# Altium schematic sheet microcontroller.SchDoc(microcontroller)
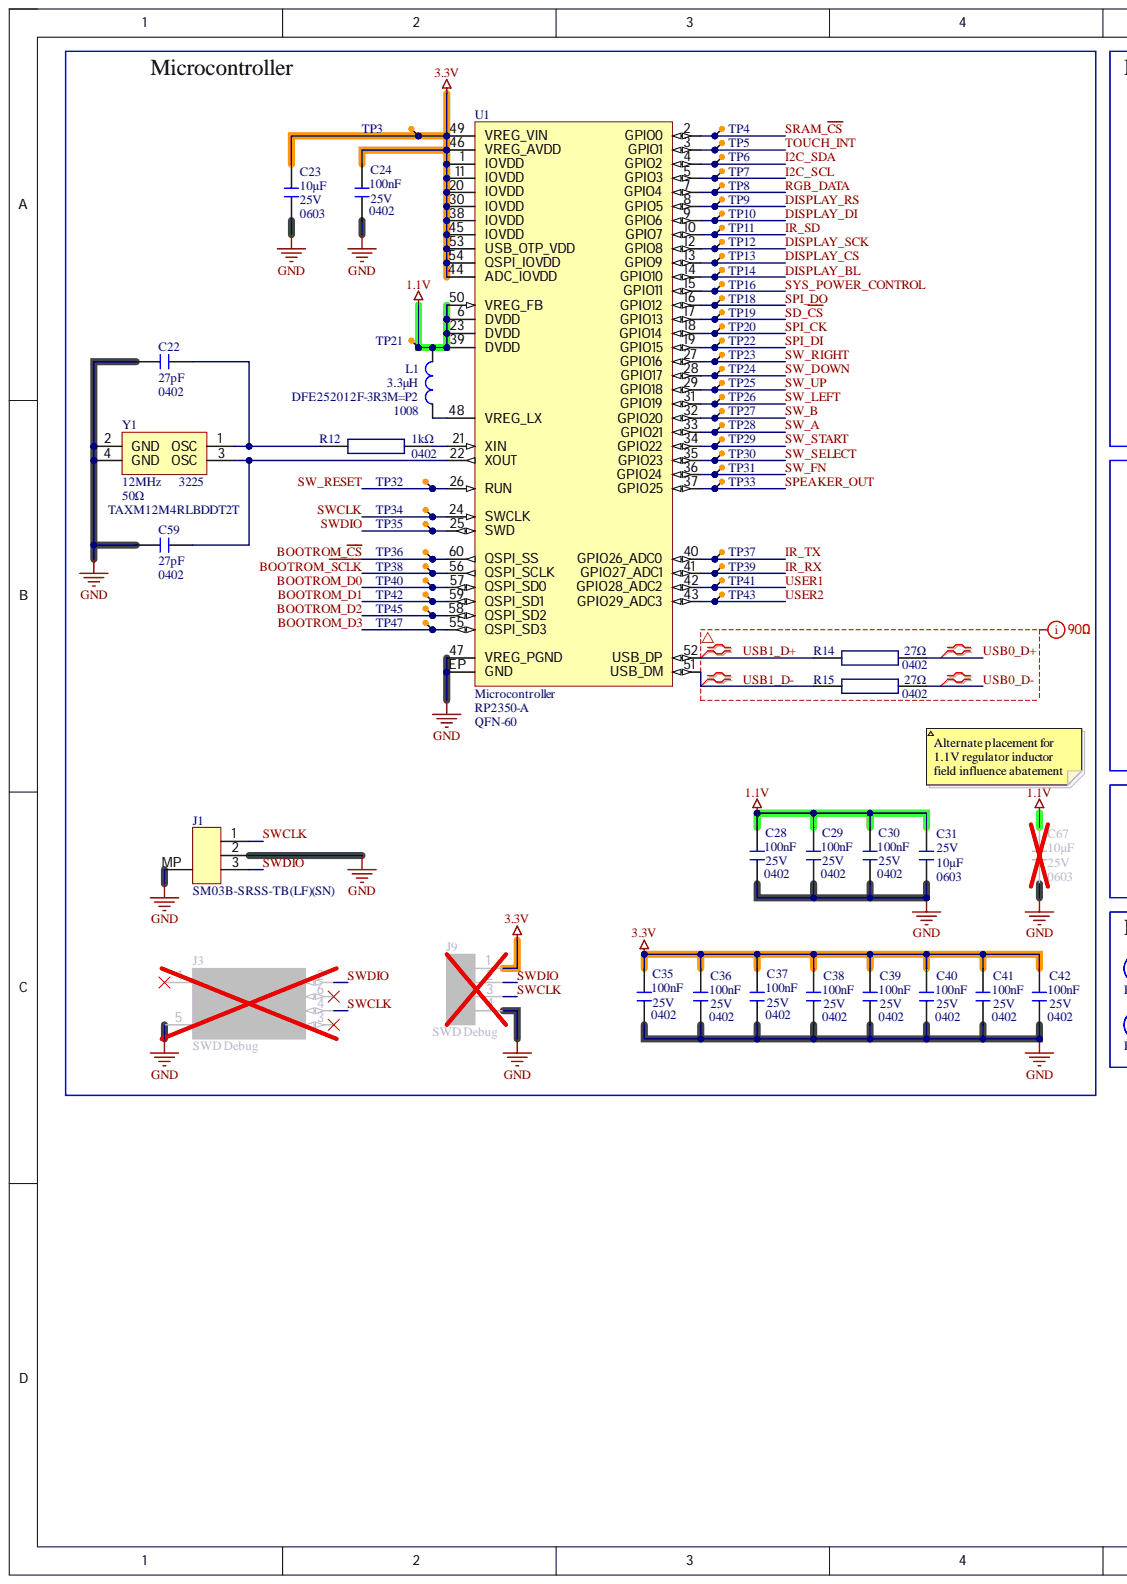
[diagram p0: microcontroller.SchDoc, left]
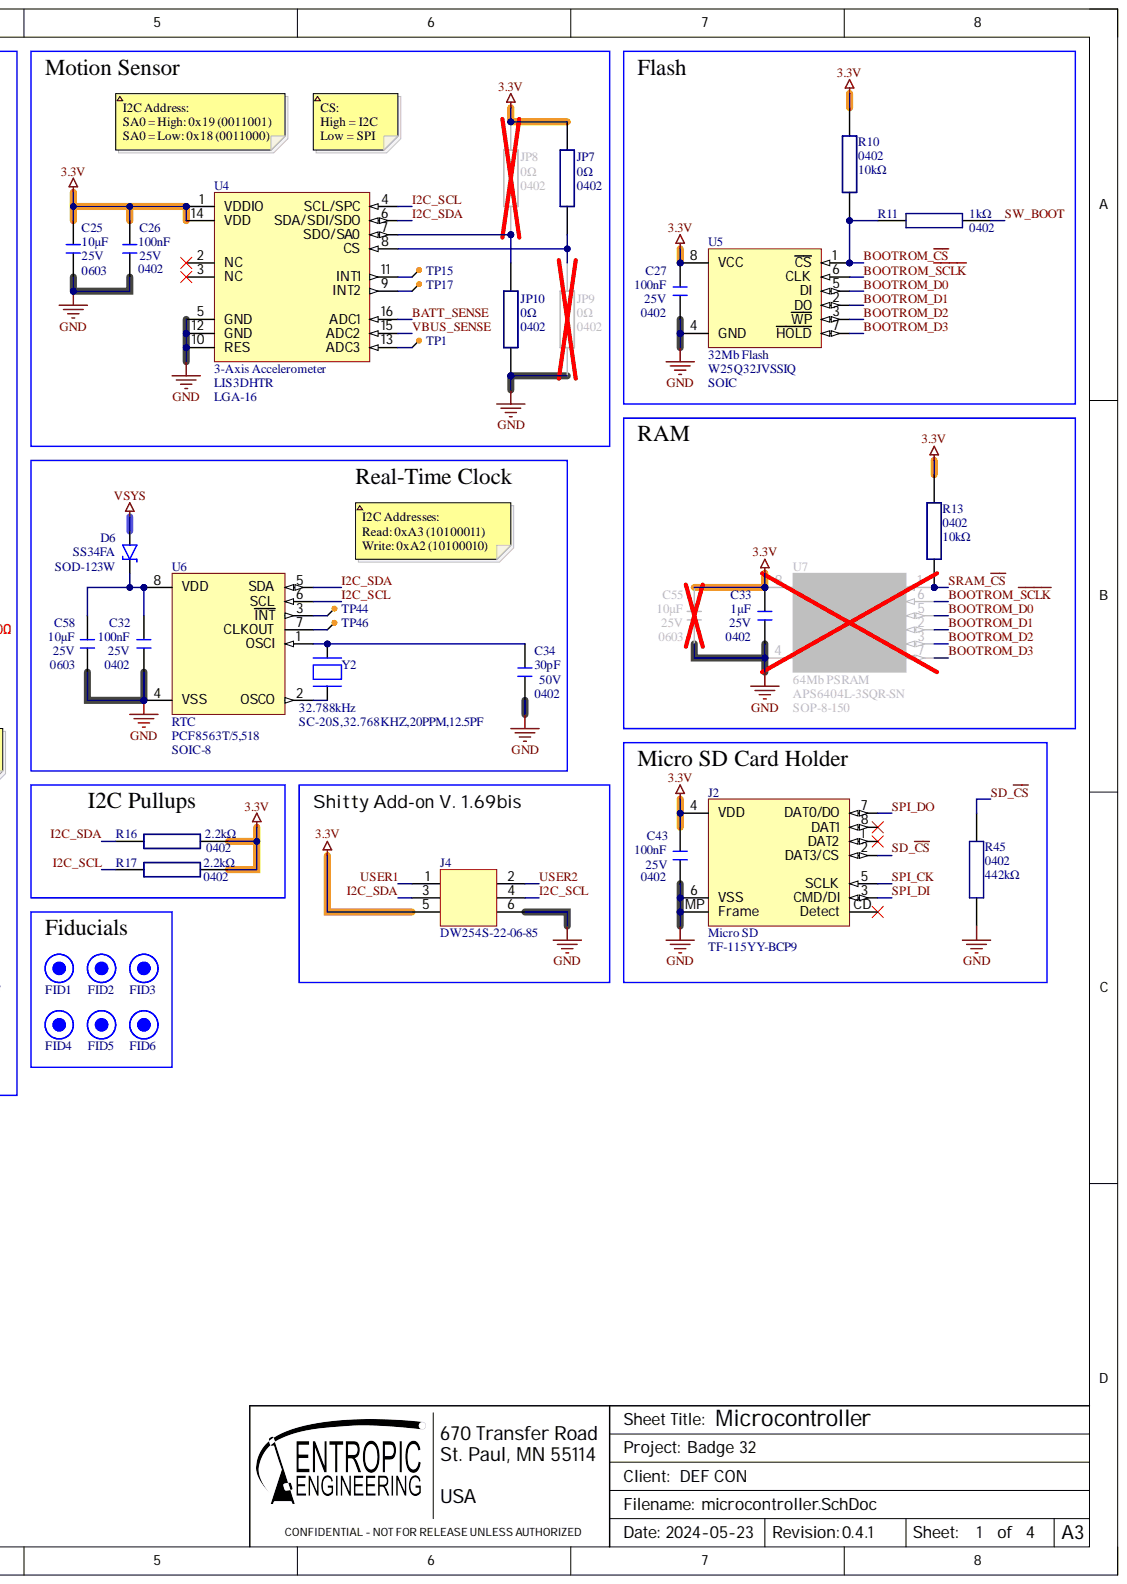
[diagram p1: microcontroller.SchDoc, right]

## Parts
C22  value 27pF  Case Code (Imperial): 0402  [0402_capacitor]  (hidden comment: CC0402JRNPO9BN270)
C23  value 10µF  Case Code (Imperial): 0603  Voltage Rating: 25V  [0603_capacitor]  (hidden comment: CL10A106MA8NRNC)
C24  Capacitance: 100nF  Case Code (Imperial): 0402  Voltage: 25V  [0402_capacitor]  (hidden comment: GRM155R71E104KE14D)
C25  value 10µF  Case Code (Imperial): 0603  Voltage Rating: 25V  [0603_capacitor]  (hidden comment: CL10A106MA8NRNC)
C26  Capacitance: 100nF  Case Code (Imperial): 0402  Voltage: 25V  [0402_capacitor]  (hidden comment: GRM155R71E104KE14D)
C27  Capacitance: 100nF  Case Code (Imperial): 0402  Voltage: 25V  [0402_capacitor]  (hidden comment: GRM155R71E104KE14D)
C28  Capacitance: 100nF  Case Code (Imperial): 0402  Voltage: 25V  [0402_capacitor]  (hidden comment: GRM155R71E104KE14D)
C29  Capacitance: 100nF  Case Code (Imperial): 0402  Voltage: 25V  [0402_capacitor]  (hidden comment: GRM155R71E104KE14D)
C30  Capacitance: 100nF  Case Code (Imperial): 0402  Voltage: 25V  [0402_capacitor]  (hidden comment: GRM155R71E104KE14D)
C31  value 10µF  Case Code (Imperial): 0603  Voltage Rating: 25V  [0603_capacitor]  (hidden comment: CL10A106MA8NRNC)
C32  Capacitance: 100nF  Case Code (Imperial): 0402  Voltage: 25V  [0402_capacitor]  (hidden comment: GRM155R71E104KE14D)
C33  Capacitance: 1µF  Size Code: 0402  Voltage: 25V  [0402_capacitor]  (hidden comment: GRM155R61E105KA12D)
C34  value 30pF  Case Code (Imperial): 0402  Voltage Rating: 50V  [0402_capacitor]  (hidden comment: CC0402JRNPO9BN300)
C35  Capacitance: 100nF  Case Code (Imperial): 0402  Voltage: 25V  [0402_capacitor]  (hidden comment: GRM155R71E104KE14D)
C36  Capacitance: 100nF  Case Code (Imperial): 0402  Voltage: 25V  [0402_capacitor]  (hidden comment: GRM155R71E104KE14D)
C37  Capacitance: 100nF  Case Code (Imperial): 0402  Voltage: 25V  [0402_capacitor]  (hidden comment: GRM155R71E104KE14D)
C38  Capacitance: 100nF  Case Code (Imperial): 0402  Voltage: 25V  [0402_capacitor]  (hidden comment: GRM155R71E104KE14D)
C39  Capacitance: 100nF  Case Code (Imperial): 0402  Voltage: 25V  [0402_capacitor]  (hidden comment: GRM155R71E104KE14D)
C40  Capacitance: 100nF  Case Code (Imperial): 0402  Voltage: 25V  [0402_capacitor]  (hidden comment: GRM155R71E104KE14D)
C41  Capacitance: 100nF  Case Code (Imperial): 0402  Voltage: 25V  [0402_capacitor]  (hidden comment: GRM155R71E104KE14D)
C42  Capacitance: 100nF  Case Code (Imperial): 0402  Voltage: 25V  [0402_capacitor]  (hidden comment: GRM155R71E104KE14D)
C43  Capacitance: 100nF  Case Code (Imperial): 0402  Voltage: 25V  [0402_capacitor]  (hidden comment: GRM155R71E104KE14D)
C55  value 10µF  Case Code (Imperial): 0603  Voltage Rating: 25V  [0603_capacitor]  (hidden comment: CL10A106MA8NRNC)
C58  value 10µF  Case Code (Imperial): 0603  Voltage Rating: 25V  [0603_capacitor]  (hidden comment: CL10A106MA8NRNC)
C59  value 27pF  Case Code (Imperial): 0402  [0402_capacitor]  (hidden comment: CC0402JRNPO9BN270)
C67  value 10µF  Case Code (Imperial): 0603  Voltage Rating: 25V  [0603_capacitor]  (hidden comment: CL10A106MA8NRNC)
D6  SS34FA  Case/Package: SOD-123W  [SOD-123]
FID1  fiducial  [fiducial-0.5]  (value not drawn)  (no BOM)
FID2  fiducial  [fiducial-0.5]  (value not drawn)  (no BOM)
FID3  fiducial  [fiducial-0.5]  (value not drawn)  (no BOM)
FID4  fiducial  [fiducial-0.5]  (value not drawn)  (no BOM)
FID5  fiducial  [fiducial-0.5]  (value not drawn)  (no BOM)
FID6  fiducial  [fiducial-0.5]  (value not drawn)  (no BOM)
J1  SWD Debug  [SM03B-SRSS-TB]
J2  Micro SD  [TF-115YY-BCP9]
J3  SWD Debug  [TAG-Connect]  (no BOM)
J4  house_part_number: DW254S-22-06-85  [HEADER_2X3P_2.54MM]  (hidden comment: HEADER_2x3_2.54MM)
J9  SWD Debug  [HEADER_1X4P_2.54MM_TH]
JP7  value 0Ω  Case Code (Imperial): 0402  [0402_resistor]  (hidden comment: RC0402FR-070RL)
JP8  value 0Ω  Case Code (Imperial): 0402  [0402_resistor]  (hidden comment: RC0402FR-070RL)
JP9  value 0Ω  Case Code (Imperial): 0402  [0402_resistor]  (hidden comment: RC0402FR-070RL)
JP10  value 0Ω  Case Code (Imperial): 0402  [0402_resistor]  (hidden comment: RC0402FR-070RL)
L1  DFE252012F-3R3M=P2  value 3.3μH  Case Code (Imperial): 1008  [INDC2520X12N]
R10  value 10kΩ  Case Code (Imperial): 0402  [0402_resistor]  (hidden comment: RC0402FR-0710KL)
R11  value 1kΩ  Case Code (Imperial): 0402  [0402_resistor]  (hidden comment: RC0402JR-071KL)
R12  value 1kΩ  Case Code (Imperial): 0402  [0402_resistor]  (hidden comment: RC0402JR-071KL)
R13  value 10kΩ  Case Code (Imperial): 0402  [0402_resistor]  (hidden comment: RC0402FR-0710KL)
R14  Resistance: 27Ω  Size Code: 0402  [0402_resistor]  (hidden comment: RMCF0402FT27R0)
R15  Resistance: 27Ω  Size Code: 0402  [0402_resistor]  (hidden comment: RMCF0402FT27R0)
R16  value 2.2kΩ  Case Code (Imperial): 0402  [0402_resistor]  (hidden comment: RC0402FR-072K2L)
R17  value 2.2kΩ  Case Code (Imperial): 0402  [0402_resistor]  (hidden comment: RC0402FR-072K2L)
R45  value 442kΩ  Case Code (Imperial): 0402  [0402_resistor]  (hidden comment: RC0402FR-07442KL)
TP1  test_point-1  [test_point-1]  (value not drawn)  (no BOM)
TP3  test_point-1  [test_point-1]  (value not drawn)  (no BOM)
TP4  test_point-1  [test_point-1]  (value not drawn)  (no BOM)
TP5  test_point-1  [test_point-1]  (value not drawn)  (no BOM)
TP6  test_point-1  [test_point-1]  (value not drawn)  (no BOM)
TP7  test_point-1  [test_point-1]  (value not drawn)  (no BOM)
TP8  test_point-1  [test_point-1]  (value not drawn)  (no BOM)
TP9  test_point-1  [test_point-1]  (value not drawn)  (no BOM)
TP10  test_point-1  [test_point-1]  (value not drawn)  (no BOM)
TP11  test_point-1  [test_point-1]  (value not drawn)  (no BOM)
TP12  test_point-1  [test_point-1]  (value not drawn)  (no BOM)
TP13  test_point-1  [test_point-1]  (value not drawn)  (no BOM)
TP14  test_point-1  [test_point-1]  (value not drawn)  (no BOM)
TP15  test_point-1  [test_point-1]  (value not drawn)  (no BOM)
TP16  test_point-1  [test_point-1]  (value not drawn)  (no BOM)
TP17  test_point-1  [test_point-1]  (value not drawn)  (no BOM)
TP18  test_point-1  [test_point-1]  (value not drawn)  (no BOM)
TP19  test_point-1  [test_point-1]  (value not drawn)  (no BOM)
TP20  test_point-1  [test_point-1]  (value not drawn)  (no BOM)
TP21  test_point-1  [test_point-1]  (value not drawn)  (no BOM)
TP22  test_point-1  [test_point-1]  (value not drawn)  (no BOM)
TP23  test_point-1  [test_point-1]  (value not drawn)  (no BOM)
TP24  test_point-1  [test_point-1]  (value not drawn)  (no BOM)
TP25  test_point-1  [test_point-1]  (value not drawn)  (no BOM)
TP26  test_point-1  [test_point-1]  (value not drawn)  (no BOM)
TP27  test_point-1  [test_point-1]  (value not drawn)  (no BOM)
TP28  test_point-1  [test_point-1]  (value not drawn)  (no BOM)
TP29  test_point-1  [test_point-1]  (value not drawn)  (no BOM)
TP30  test_point-1  [test_point-1]  (value not drawn)  (no BOM)
TP31  test_point-1  [test_point-1]  (value not drawn)  (no BOM)
TP32  test_point-1  [test_point-1]  (value not drawn)  (no BOM)
TP33  test_point-1  [test_point-1]  (value not drawn)  (no BOM)
TP34  test_point-1  [test_point-1]  (value not drawn)  (no BOM)
TP35  test_point-1  [test_point-1]  (value not drawn)  (no BOM)
TP36  test_point-1  [test_point-1]  (value not drawn)  (no BOM)
TP37  test_point-1  [test_point-1]  (value not drawn)  (no BOM)
TP38  test_point-1  [test_point-1]  (value not drawn)  (no BOM)
TP39  test_point-1  [test_point-1]  (value not drawn)  (no BOM)
TP40  test_point-1  [test_point-1]  (value not drawn)  (no BOM)
TP41  test_point-1  [test_point-1]  (value not drawn)  (no BOM)
TP42  test_point-1  [test_point-1]  (value not drawn)  (no BOM)
TP43  test_point-1  [test_point-1]  (value not drawn)  (no BOM)
TP44  test_point-1  [test_point-1]  (value not drawn)  (no BOM)
TP45  test_point-1  [test_point-1]  (value not drawn)  (no BOM)
TP46  test_point-1  [test_point-1]  (value not drawn)  (no BOM)
TP47  test_point-1  [test_point-1]  (value not drawn)  (no BOM)
U1  Microcontroller  Package: QFN-60  [PQFN40P700X700X80_HS-61N]
U4  3-Axis Accelerometer  Case/Package: LGA-16  [LGA50P300X300X100-16N]
U5  32Mb Flash  Case/Package: SOIC  Category: Flash  Density: 32Mb  [SOIC-8_wide]
U6  RTC  Case/Package: SOIC-8  [SOIC127P600X175-8N]
U7  64Mb PSRAM  Case/Package: SOP-8-150  [SOIC127P600X175-8N]
Y1  TAXM12M4RLBDDT2T  value 12MHz  Case/Package: 3225  ESR: 50Ω  [3225_crystal]
Y2  SC-20S,32.768KHZ,20PPM,12.5PF  Frequency: 32.788kHz  [2012_crystal]

## Nets
1.1V: C28.2 C29.2 C30.2 C31.2 C67.2 L1.2 TP21.1 U1.6(DVDD) U1.23(DVDD) U1.39(DVDD) U1.50(VREG_FB)
3.3V: C23.2 C24.2 C25.2 C26.2 C27.2 C33.2 C35.2 C36.2 C37.2 C38.2 C39.2 C40.2 C41.2 C42.2 C43.2 C55.2 J2.4(VDD) J4.5 J9.1 JP7.1 JP8.1 R10.2 R13.2 R16.1 R17.1 TP3.1 U1.1(IOVDD) U1.11(IOVDD) U1.20(IOVDD) U1.30(IOVDD) U1.38(IOVDD) U1.44(ADC_IOVDD) U1.45(IOVDD) U1.46(VREG_AVDD) U1.49(VREG_VIN) U1.53(USB_OTP_VDD) U1.54(QSPI_IOVDD) U4.1(VDDIO) U4.14(VDD) U5.8(VCC) U7.8(VCC)
GND: C22.1 C23.1 C24.1 C25.1 C26.1 C27.1 C28.1 C29.1 C30.1 C31.1 C32.1 C33.1 C34.1 C35.1 C36.1 C37.1 C38.1 C39.1 C40.1 C41.1 C42.1 C43.1 C55.1 C58.1 C59.1 C67.1 J1.2 J1.MP J2.6(VSS) J2.MP(Frame) J3.5(GND) J4.6 J9.4 JP9.2 JP10.2 R45.1 U1.47(VREG_PGND) U1.EP(GND) U4.5(GND) U4.10(RES) U4.12(GND) U5.4(GND) U6.4(VSS) U7.4(GND) Y1.2(GND) Y1.4(GND)
VSYS: D6.A
BATT_SENSE: U4.16(ADC1)
BOOTROM_~{CS}: R10.1 R11.1 TP36.1 U1.60(QSPI_SS) U5.1(CS)
BOOTROM_D0: TP40.1 U1.57(QSPI_SD0) U5.5(DI) U7.5(DI/DQ0)
BOOTROM_D1: TP42.1 U1.59(QSPI_SD1) U5.2(DO) U7.2(DO/DQ1)
BOOTROM_D2: TP45.1 U1.58(QSPI_SD2) U5.3(WP) U7.3(DQ2)
BOOTROM_D3: TP47.1 U1.55(QSPI_SD3) U5.7(HOLD) U7.7(DQ3)
BOOTROM_~{SCLK}: TP38.1 U1.56(QSPI_SCLK) U5.6(CLK) U7.6(CLK)
DISPLAY_BL: TP14.1 U1.14(GPIO10)
DISPLAY_CS: TP13.1 U1.13(GPIO9)
DISPLAY_DI: TP10.1 U1.9(GPIO6)
DISPLAY_RS: TP9.1 U1.8(GPIO5)
DISPLAY_SCK: TP12.1 U1.12(GPIO8)
I2C_SCL: J4.4 R17.2 TP7.1 U1.5(GPIO3) U4.4(SCL/SPC) U6.6(SCL)
I2C_SDA: J4.3 R16.2 TP6.1 U1.4(GPIO2) U4.6(SDA/SDI/SDO) U6.5(SDA)
IR_RX: TP39.1 U1.41(GPIO27_ADC1)
IR_SD: TP11.1 U1.10(GPIO7)
IR_TX: TP37.1 U1.40(GPIO26_ADC0)
RGB_DATA: TP8.1 U1.7(GPIO4)
SD_~{CS}: J2.2(DAT3/CS) R45.2 TP19.1 U1.17(GPIO13)
SPEAKER_OUT: TP33.1 U1.37(GPIO25)
SPI_CK: J2.5(SCLK) TP20.1 U1.18(GPIO14)
SPI_DI: J2.3(CMD/DI) TP22.1 U1.19(GPIO15)
SPI_DO: J2.7(DAT0/DO) TP18.1 U1.16(GPIO12)
SRAM_~{CS}: R13.1 TP4.1 U1.2(GPIO0) U7.1(CS)
SW_A: TP28.1 U1.33(GPIO21)
SW_B: TP27.1 U1.32(GPIO20)
SW_BOOT: R11.2
SW_DOWN: TP24.1 U1.28(GPIO17)
SW_FN: TP31.1 U1.36(GPIO24)
SW_LEFT: TP26.1 U1.31(GPIO19)
SW_RESET: TP32.1 U1.26(RUN)
SW_RIGHT: TP23.1 U1.27(GPIO16)
SW_SELECT: TP30.1 U1.35(GPIO23)
SW_START: TP29.1 U1.34(GPIO22)
SW_UP: TP25.1 U1.29(GPIO18)
SWCLK: J1.1 J3.4(SWCLK) J9.3 TP34.1 U1.24(SWCLK)
SWDIO: J1.3 J3.2(SWDIO) J9.2 TP35.1 U1.25(SWD)
SYS_POWER_CONTROL: TP16.1 U1.15(GPIO11)
TOUCH_INT: TP5.1 U1.3(GPIO1)
USB0_D+: R14.2
USB0_D-: R15.2
USB1_D+: R14.1 U1.52(USB_DP)
USB1_D-: R15.1 U1.51(USB_DM)
USER1: J4.1 TP41.1 U1.42(GPIO28_ADC2)
USER2: J4.2 TP43.1 U1.43(GPIO29_ADC3)
VBUS_SENSE: U4.15(ADC2)
NetC22_2: C22.2 R12.1 Y1.1(OSC)
NetC32_2: C32.2 C58.2 D6.K U6.8(VDD)
NetC34_2: C34.2 U6.1(OSCI) Y2.2
NetC59_2: C59.2 U1.22(XOUT) Y1.3(OSC)
NetJP7_2: JP7.2 JP9.1 U4.8(CS)
NetJP8_2: JP8.2 JP10.1 U4.7(SDO/SA0)
NetL1_1: L1.1 U1.48(VREG_LX)
NetR12_2: R12.2 U1.21(XIN)
NetTP1_1: TP1.1 U4.13(ADC3)
NetTP15_1: TP15.1 U4.11(INT1)
NetTP17_1: TP17.1 U4.9(INT2)
NetTP44_1: TP44.1 U6.3(INT)
NetTP46_1: TP46.1 U6.7(CLKOUT)
NetU6_2: U6.2(OSCO) Y2.1

Not connected: J2 1(DAT2) 8(DAT1) CD(Detect); J3 1(VCC) 3(nRST) 6(SWO); U4 2(NC) 3(NC)

## Library data of the parts
C22 C59 = CC0402JRNPO9BN270: 27 pF, 25 V, X7R, 0402 Chip capacitor; house_part_number: C-27pF-0402-002; Manufacturer: Yageo; Dielectric: C0G; Tolerance: 5%; Voltage Rating: 50V; Case Code (Metric): 1005; Case/Package: 0402; Category: Ceramic Capacitors; Component Kind: Standard; ComponentLink1Description: https://datasheet.ciiva.com/26862/getdatasheetpartid-403452-26862597.pdf?src-supplier=Verical; ComponentLink1URL: https://datasheet.ciiva.com/26862/getdatasheetpartid-403452-26862597.pdf?src-supplier=Verical; ComponentLink2Description: https://datasheet.ciiva.com/28450/1443161-28450249.pdf?src-supplier=Element14; ComponentLink2URL: https://datasheet.ciiva.com/28450/1443161-28450249.pdf?src-supplier=Element14; ComponentLink3Description: https://datasheet.ciiva.com/176/mlccorderingcode-176355.pdf?src-supplier=Digikey; ComponentLink3URL: https://datasheet.ciiva.com/176/mlccorderingcode-176355.pdf?src-supplier=Digikey; ComponentLink4Description: https://datasheet.ciiva.com/176/mlccorderingcode-176354.pdf?src-supplier=Digikey; ComponentLink4URL: https://datasheet.ciiva.com/176/mlccorderingcode-176354.pdf?src-supplier=Digikey; ComponentLink5Description: https://datasheet.ciiva.com/176/mlccorderingcode-176356.pdf?src-supplier=Digikey; ComponentLink5URL: https://datasheet.ciiva.com/176/mlccorderingcode-176356.pdf?src-supplier=Digikey; ComponentLink6Description: https://datasheet.ciiva.com/13386/upy-gp-np0-16v-to-50v-8-13386281.pdf?src-supplier=Mouser; ComponentLink6URL: https://datasheet.ciiva.com/13386/upy-gp-np0-16v-to-50v-8-13386281.pdf?src-supplier=Mouser; Depth: 0.5mm; Lead Free: Lead Free; Length: 1mm; Manufacturer Part Number: CC0402JRNPO9BN270; Max Operating Temperature: 125°C; Min Operating Temperature: -55°C; Mounting Technology: Surface Mount; Package Quantity: 1; Packaging: Tape and Reel; Pins: 2; Pricing: 10000=0.0024, 40000=0.0022, 150000=0.0021, 400000=0.002, 1000000=0.0019 (USD); Radiation Hardening: No; Reach SVHC Compliant: No; RoHS: Yes; RoHS Compliant: Yes; Series: CC; Stock: 1230000; Supplier 1: Future Electronics; Supplier Part Number 1: 9741513; Termination: SMD/SMT; Thickness: 0.022inch; Voltage Rating (DC): 50V; Width: 0.02inch; Library Name: defcon_badge.SchLib
C23 C25 C31 C55 C58 C67 = CL10A106MA8NRNC: 10 µF, 25 V, X5R, 0603 Chip capacitor; house_part_number: C-10uF-0603-002; Manufacturer: Samsung; Material: 4241ConductorMaterial; Tolerance: 20%; Case Code (Metric): 1608; Case/Package: 0603; Category: Ceramic Capacitors; Component Kind: Standard; ComponentLink1Description: https://datasheet.ciiva.com/25766/cl10a106ma8nrnc-25766562.pdf?src-supplier=Digikey; ComponentLink1URL: https://datasheet.ciiva.com/25766/cl10a106ma8nrnc-25766562.pdf?src-supplier=Digikey; Height: 900um; Lead Free: Lead Free; Manufacturer Part Number: CL10A106MA8NRNC; Max Operating Temperature: 85°C; Min Operating Temperature: -55°C; Mounting Technology: Surface Mount; Packaging: Cut Tape; Pricing: 4000=0.0511, 8000=0.046, 12000=0.0434, 28000=0.0413, 100000=0.0356 (USD); RoHS: Yes; RoHS Compliant: Yes; Series: CL; Stock: 632000; Supplier 1: Arrow Electronics; Supplier Part Number 1: CL10A106MA8NRNC; Library Name: defcon_badge.SchLib
C24 C26-C30 C32 C35-C43 = GRM155R71E104KE14D: 100 nF, 25 V, X7R, 0402 Chip capacitor; house_part_number: C-100nF-0402-002; Manufacturer: Murata; Dielectric Material: Ceramic; Tolerance: 10%; Case Code (Metric): 1005; Case/Package: 0402; Category: Ceramic Capacitors; Component Kind: Standard; ComponentLink1Description: http://www.farnell.com/datasheets/1872206.pdf?src-supplier=Farnell; ComponentLink1URL: http://www.farnell.com/datasheets/1872206.pdf?src-supplier=Farnell; ComponentLink2Description: http://components.arrow.com/part/dataview/0323_06361249?src-supplier=Arrow+Europe; ComponentLink2URL: http://components.arrow.com/part/dataview/0323_06361249?src-supplier=Arrow+Europe; ComponentLink3Description: http://uk.rs-online.com/webdocs/144c/0900766b8144c113.pdf?src-supplier=RSComponents; ComponentLink3URL: http://uk.rs-online.com/webdocs/144c/0900766b8144c113.pdf?src-supplier=RSComponents; ComponentLink4Description: http://www.farnell.com/datasheets/2048008.pdf?src-supplier=Farnell; ComponentLink4URL: http://www.farnell.com/datasheets/2048008.pdf?src-supplier=Farnell; ComponentLink5Description: http://www.mouser.com/ds/2/281/c02e-2905.pdf?src-supplier=Mouser; ComponentLink5URL: http://www.mouser.com/ds/2/281/c02e-2905.pdf?src-supplier=Mouser; ComponentLink6Description: http://www.farnell.com/datasheets/2048008.pdf?src-supplier=Newark; ComponentLink6URL: http://www.farnell.com/datasheets/2048008.pdf?src-supplier=Newark; ComponentLink7Description: https://search.murata.co.jp/Ceramy/image/img/A01X/G101/ENG/GRM155R71E104KE14-01.pdf?src-supplier=Digi-Key; ComponentLink7URL: https://search.murata.co.jp/Ceramy/image/img/A01X/G101/ENG/GRM155R71E104KE14-01.pdf?src-supplier=Digi-Key; ComponentLink8Description: http://www.farnell.com/datasheets/2048008.pdf?src-supplier=Element14; ComponentLink8URL: http://www.farnell.com/datasheets/2048008.pdf?src-supplier=Element14; ComponentLink9Description: http://psearch.en.murata.com/capacitor/product/GRM155R71E104KE14%23.pdf?src-supplier=Avnet; ComponentLink9URL: http://psearch.en.murata.com/capacitor/product/GRM155R71E104KE14%23.pdf?src-supplier=Avnet; Depth: 0.5mm; Dielectric: X7R; Height: 0.5mm; Lead Free: Lead Free; Length: 1mm; Manufacturer Part Number: GRM155R71E104KE14D; Max Operating Temperature: 125°C; Min Operating Temperature: -55°C; Mount: Surface Mount; Package Quantity: 10000; Packaging: Tape and Reel; Pricing 1: 1=0.10000, 10=0.02700, 50=0.01460, 100=0.01190, 500=0.00848, 1000=0.00666, 2500=0.00605, 5000=0.00557 (USD); REACH SVHC: Unknown; Resistor Type: Ceramic; RoHS: Yes; RoHS Compliant: Yes; Supplier 1: Digi-Key; Supplier Part Number 1: 490-10698-1-ND; Termination: SMD/SMT; Thickness: 0.022inch; Voltage Rating: 25V; Voltage Rating (DC): 25V; Weight: 0.000212oz; Width: 0.02inch; Library Name: defcon_badge.SchLib
C33 = GRM155R61E105KA12D: 1 µF, 25 V, X5R, 0402 Chip capacitor; house_part_number: C-1uF-0402-002; Manufacturer: Murata; Capacitor Type: Ceramic; Multilayer: Yes; Negative Tolerance: 10%; Category: Ceramic Capacitors; Component Kind: Standard; ComponentLink1Description: https://datasheet.ciiva.com:443/pdfs/VipMasterIC/IC/MURE/MURE-S-A0004362469/MURE-S-A0004364524-1.pdf?src-supplier=IHS+Markit; ComponentLink1URL: https://datasheet.ciiva.com:443/pdfs/VipMasterIC/IC/MURE/MURE-S-A0004362469/MURE-S-A0004364524-1.pdf?src-supplier=IHS+Markit; ComponentLink2Description: https://datasheet.ciiva.com/7719/1666664-7719006.pdf?src-supplier=Element14; ComponentLink2URL: https://datasheet.ciiva.com/7719/1666664-7719006.pdf?src-supplier=Element14; ComponentLink3Description: https://datasheet.ciiva.com/22732/1666664-22732122.pdf?src-supplier=Element14; ComponentLink3URL: https://datasheet.ciiva.com/22732/1666664-22732122.pdf?src-supplier=Element14; Dielectric Material: Ceramic; Height: 0.5mm; JESD-609 Code: e3; Length: 1mm; Manufacturer Part Number: GRM155R61E105KA12D; Max Operating Temperature: 85°C; Min Operating Temperature: -55°C; Mount: Surface Mount; Number of Pins: 2; Package Shape: Rectangular; Package Style: SMTMeter; Packaging: Tape and Reel; Positive Tolerance: 10%; Pricing 1: 1=0.11000, 10=0.08300, 50=0.04560, 100=0.03720, 500=0.02656, 1000=0.02087, 2500=0.01898, 5000=0.01746 (USD); REACH SVHC: Yes; RoHS: Yes; RoHS Compliant: Yes; Stock 1: 145965; Supplier 1: Digi-Key; Supplier Part Number 1: 490-10017-1-ND; Temperature Characteristics Code: X5R; Terminal Finish: Nickel; Termination: Wraparound; Width: 0.5mm; Library Name: defcon_badge.SchLib
C34 = CC0402JRNPO9BN300: 30 pF, 50 V, C0G, 0402 Chip capacitor; house_part_number: C-30pF-0402-002; Manufacturer: Yageo; Dielectric: NP0; Temperature Coefficient: 30ppm/°C; Tolerance: 5%; Case Code (Metric): 1005; Case/Package: 0402; Category: Ceramic Capacitors; Component Kind: Standard; ComponentLink1Description: https://datasheet.ciiva.com/13263/upy-gp-np0-16v-to-50v-8-3714-13263961.pdf?src-supplier=Mouser; ComponentLink1URL: https://datasheet.ciiva.com/13263/upy-gp-np0-16v-to-50v-8-3714-13263961.pdf?src-supplier=Mouser; Halogen Free: 5694HalogenFree; Lead Free: Lead Free; Length: 0.039inch; Manufacturer Part Number: CC0402JRNPO9BN300; Max Operating Temperature: 125°C; Min Operating Temperature: -55°C; Mounting Technology: Surface Mount; Packaging: Tape and Reel; Pins: 2; Pricing: 1=0.1, 10=0.035, 50=0.0202, 100=0.0156, 500=0.01174, 1000=0.00922, 2500=0.00838, 5000=0.00771 (USD); RoHS: Yes; RoHS Compliant: Yes; Series: CC; Stock: 48712; Supplier 1: Digikey; Supplier Part Number 1: 311-1666-1-ND; Termination: SMD/SMT; Thickness: 0.022inch; Voltage Rating (DC): 50V; Weight: 2.3E-05oz; Width: 0.02inch; Library Name: defcon_badge.SchLib
D6 = SS34FA: 40 V, 3 A Schottky Rectifier, SOD-123FA; house_part_number: D-SCHOTTKY-3A-SOD123F-002; Manufacturer: ON Semiconductor / Fairchild; Average Rectified Current: 3A; Element Configuration: Single; Max Forward Surge Current (Ifsm): 80A; Max Repetitive Reverse Voltage (Vrrm): 40V; Category: Schottky Diodes; Component Kind: Standard; ComponentLink1Description: http://www.farnell.com/datasheets/2552647.pdf?src-supplier=Farnell; ComponentLink1URL: http://www.farnell.com/datasheets/2552647.pdf?src-supplier=Farnell; ComponentLink2Description: http://www.mouser.com/ds/2/149/SS34FA-1012641.pdf?src-supplier=Mouser; ComponentLink2URL: http://www.mouser.com/ds/2/149/SS34FA-1012641.pdf?src-supplier=Mouser; ComponentLink3Description: http://www.farnell.com/datasheets/2552647.pdf?src-supplier=Element14; ComponentLink3URL: http://www.farnell.com/datasheets/2552647.pdf?src-supplier=Element14; ComponentLink4Description: http://www.farnell.com/datasheets/2552647.pdf?src-supplier=Newark; ComponentLink4URL: http://www.farnell.com/datasheets/2552647.pdf?src-supplier=Newark; ComponentLink5Description: https://www.fairchildsemi.com/datasheets/SS/SS34FA.pdf?src-supplier=Digikey; ComponentLink5URL: https://www.fairchildsemi.com/datasheets/SS/SS34FA.pdf?src-supplier=Digikey; ComponentLink6Description: https://www.onsemi.com/pub/Collateral/SS36FA-D.PDF?src-supplier=Digikey; ComponentLink6URL: https://www.onsemi.com/pub/Collateral/SS36FA-D.PDF?src-supplier=Digikey; ComponentLink7Description: https://datasheet.octopart.com/SS34FA-ON-Semiconductor-datasheet-137713515.pdf?src-supplier=TME; ComponentLink7URL: https://datasheet.octopart.com/SS34FA-ON-Semiconductor-datasheet-137713515.pdf?src-supplier=TME; Manufacturer Part Number: SS34FA; Max Reverse Voltage (DC): 40V; Mounting Technology: Surface Mount; Packaging: Cut Tape; Pricing: 1=0.469, 25=0.4, 50=0.341, 100=0.281, 250=0.251 (USD); RoHS: Yes; RoHS Compliant: Yes; Series: Automotive, AEC-Q101; Stock: 2402; Supplier: Avnet; Supplier 1: LCSC; Supplier Part Number: 01AC9736; Supplier Part Number 1: C719833; Weight: 0.019g; Library Name: defcon_badge.SchLib
FID1-FID6 = fiducial: 0.5mm diameter round fiducial; house_part_number: Disc-0.5; Library Name: defcon_badge.SchLib
J1 = SM03B-SRSS-TB(LF)(SN): 3-Pin JST-SH connector, 1mm pitch, right-angle SMD; Manufacturer: JST; Category: Connectors; Component Kind: Standard; ComponentLink1Description: https://datasheet.ciiva.com/26894/getdatasheetpartid-708885-26894671.pdf?src-supplier=Verical; ComponentLink1URL: https://datasheet.ciiva.com/26894/getdatasheetpartid-708885-26894671.pdf?src-supplier=Verical; ComponentLink2Description: https://datasheet.ciiva.com/16394/sm03b-srss-tblfsn-16394332.pdf?src-supplier=Future+Electronics; ComponentLink2URL: https://datasheet.ciiva.com/16394/sm03b-srss-tblfsn-16394332.pdf?src-supplier=Future+Electronics; ComponentLink3Description: https://datasheet.ciiva.com/8052/91630-8052263.pdf?src-supplier=Element14; ComponentLink3URL: https://datasheet.ciiva.com/8052/91630-8052263.pdf?src-supplier=Element14; house_part_number: SM03B-SRSS-TB; Manufacturer Part Number: SM03B-SRSS-TB(LF)(SN); Pricing 1: 5=0.1423, 50=0.1131, 150=0.0988, 500=0.086, 3000=0.0797, 6000=0.0776 (USD); RoHS: Yes; Stock 1: 559145; Supplier 1: LCSC; Supplier Part Number 1: C160403; Library Name: defcon_badge.SchLib
J2 = TF-115YY-BCP9: Micro SD card holder; Manufacturer: Xunpu; Category: Uncategorized; Component Kind: Standard; house_part_number: TF-115YY-BCP9; Manufacturer Part Number: TF-115YY-BCP9; Pricing 1: 10=0.0587, 100=0.0477, 300=0.0423, 1000=0.0382, 5000=0.035, 10000=0.0334 (USD); RoHS: No; Stock 1: 3100; Supplier 1: LCSC; Supplier Part Number 1: C5374810; Library Name: defcon_badge.SchLib
J3 = TAG_CONN_CTX: Mates with TC-2030-CTX-NL; house_part_number: type-value-case-grade; Library Name: defcon_badge.SchLib
J4 = HEADER_2x3_2.54MM: 0.1" socket, 3x2, SMD; Component Kind: Standard; Manufacturer Part Number: DW254S-22-06-85; Library Name: defcon_badge.SchLib
J9 = HEADER_1x4_2.54MM_TH: Component Kind: Standard; Library Name: defcon_badge.SchLib
JP7-JP10 = RC0402FR-070RL: 0 Ω, 0402 Chip resistor (jumper); house_part_number: R-0-0402-1%-001; Manufacturer: Yageo; Max Power Dissipation: 63mW; Power Rating: 62.5mW; Temperature Coefficient: 200ppm/°C; Tolerance: 1%; Voltage Rating: 50V; Case Code (Metric): 1005; Case/Package: 0402; Category: Chip SMD Resistors; Component Kind: Standard; Contact Plating: Tin,Matte; Features: Moisture Resistant; Height: 0.35mm; Lead Free: 5697LeadFree; Length: 1mm; Manufacturer Part Number: RC0402FR-070RL; Max Operating Temperature: 155°C; Min Operating Temperature: -55°C; Mounting Technology: Surface Mount; Number of Terminations: 2; Packaging: Tape and Reel; Pricing: 10000=0.00093 (USD); RoHS: Yes; RoHS Compliant: Yes; Series: RC; Stock: 580000; Supplier 1: Avnet; Supplier Part Number 1: RC0402FR-070RL; Termination: SMD/SMT; Width: 0.5mm; Library Name: defcon_badge.SchLib
L1 = DFE252012F-3R3M=P2: 3.3 μH, 1.8 A, 1008 Inductor; house_part_number: L-3.3uH-1008-002; Manufacturer: Murata; Core Material: Ferrite; Current Rating: 1.8A; DC Resistance (DCR): 135mR; Saturation Current: 2.8A; Shielding: Shielded; Test Frequency: 1MHz; Tolerance: 20%; Case Code (Metric): 2520; Case/Package: 1008; Category: Fixed Inductors; Component Kind: Standard; ComponentLink1Description: http://www.murata.com/~/media/webrenewal/products/inductor/chip/tokoproducts/wirewoundmetalalloychiptype/m_dfe252012f.ashx?src-supplier=Digikey; ComponentLink1URL: http://www.murata.com/~/media/webrenewal/products/inductor/chip/tokoproducts/wirewoundmetalalloychiptype/m_dfe252012f.ashx?src-supplier=Digikey; ComponentLink2Description: https://www.murata.com/~/media/webrenewal/products/inductor/chip/tokoproducts/wirewoundmetalalloychiptype/m_dfe252012f.ashx?src-supplier=Digikey; ComponentLink2URL: https://www.murata.com/~/media/webrenewal/products/inductor/chip/tokoproducts/wirewoundmetalalloychiptype/m_dfe252012f.ashx?src-supplier=Digikey; ComponentLink3Description: https://datasheet.octopart.com/DFE252012F-3R3M%3DP2-Murata-datasheet-150009032.pdf?src-supplier=Arrow.cn; ComponentLink3URL: https://datasheet.octopart.com/DFE252012F-3R3M%3DP2-Murata-datasheet-150009032.pdf?src-supplier=Arrow.cn; Height - Seated (Max): 0.047inch; Length: 0.098inch; Manufacturer Part Number: DFE252012F-3R3M=P2; Max Operating Temperature: 125°C; Min Operating Temperature: -40°C; Mounting Technology: Surface Mount; Packaging: Cut Tape; Pricing: 1=0.1101 (USD); RoHS: Yes; RoHS Compliant: Yes; Series: DFE252012F; Stock: 8; Supplier 1: Arrow Electronics; Supplier Part Number 1: DFE252012F-3R3M=P2; Width: 0.079inch; Library Name: defcon_badge.SchLib
R10 R13 = RC0402FR-0710KL: 10 kΩ, 0402 Chip resistor; house_part_number: R-10k-0402-1%-001; Manufacturer: Yageo; Max Power Dissipation: 63mW; Power Rating: 62.5mW; Resistance Tolerance: 1%; Temperature Coefficient: 100ppm/°C; Voltage Rating: 50V; Case Code (Metric): 1005; Case/Package: 0402; Category: Chip SMD Resistors; Component Kind: Standard; ComponentLink1Description: https://datasheet.ciiva.com/12667/pyu-rc0402-51-rohs-l-6-r-12667067.pdf?src-supplier=Mouser; ComponentLink1URL: https://datasheet.ciiva.com/12667/pyu-rc0402-51-rohs-l-6-r-12667067.pdf?src-supplier=Mouser; Construction: Rectangular; Depth: 0.5mm; Features: Moisture Resistant; Lead Free: 5697LeadFree; Lead Pitch: 0.2mm; Length: 1mm; Manufacturer Part Number: RC0402FR-0710KL; Max Operating Temperature: 155°C; Military Standard: Not; Min Operating Temperature: -55°C; Number of Terminations: 2; Packaging: Tape and Reel; Pins: 2; Pricing: 1=0.1, 10=0.015, 50=0.0088, 100=0.0073, 500=0.00488, 1000=0.00417, 5000=0.00297 (USD); Reach SVHC Compliant: Unknown; Resistor Type: Thick Film; RoHS: Yes; RoHS Compliant: Yes; Series: RC; Stock: 9380606; Supplier 1: Digikey; Supplier Part Number 1: 311-10.0KLRCT-ND; Termination: SMD/SMT; Tolerance: 1%; Voltage Rating (DC): 50V; Width: 0.5mm; Library Name: defcon_badge.SchLib
R11 R12 = RC0402JR-071KL: 1 kΩ, 0402 Chip resistor; house_part_number: R-1k-0402-1%-001; Manufacturer: Yageo; Max Power Dissipation: 63mW; Power Rating: 62.5mW; Resistance Tolerance: 5%; Temperature Coefficient: 100ppm/°C; Voltage Rating: 50V; Case Code (Metric): 1005; Case/Package: 0402; Category: Chip SMD Resistors; Component Kind: Standard; ComponentLink1Description: https://datasheet.ciiva.com/7983/1018594-7983558.pdf?src-supplier=Element14; ComponentLink1URL: https://datasheet.ciiva.com/7983/1018594-7983558.pdf?src-supplier=Element14; ComponentLink2Description: https://datasheet.ciiva.com/2452/pyu-r-int-thick-7-2452756.pdf?src-supplier=Digikey; ComponentLink2URL: https://datasheet.ciiva.com/2452/pyu-r-int-thick-7-2452756.pdf?src-supplier=Digikey; ComponentLink3Description: https://datasheet.ciiva.com/2452/pyu-r-int-thick-7-2452757.pdf?src-supplier=Digikey; ComponentLink3URL: https://datasheet.ciiva.com/2452/pyu-r-int-thick-7-2452757.pdf?src-supplier=Digikey; ComponentLink4Description: https://datasheet.ciiva.com/2452/pyu-r-int-thick-7-2452755.pdf?src-supplier=Digikey; ComponentLink4URL: https://datasheet.ciiva.com/2452/pyu-r-int-thick-7-2452755.pdf?src-supplier=Digikey; ComponentLink5Description: https://datasheet.ciiva.com/7850/1018594-7850170.pdf?src-supplier=Element14; ComponentLink5URL: https://datasheet.ciiva.com/7850/1018594-7850170.pdf?src-supplier=Element14; ComponentLink6Description: https://datasheet.ciiva.com/7850/1018594-7850171.pdf?src-supplier=Element14; ComponentLink6URL: https://datasheet.ciiva.com/7850/1018594-7850171.pdf?src-supplier=Element14; ComponentLink7Description: https://datasheet.ciiva.com/17081/pyu-rc0402-51-rohs-l-6-r-17081438.pdf?src-supplier=Future+Electronics; ComponentLink7URL: https://datasheet.ciiva.com/17081/pyu-rc0402-51-rohs-l-6-r-17081438.pdf?src-supplier=Future+Electronics; Features: Moisture Resistant; Height: 0.016inch; Lead Free: 5697LeadFree; Length: 0.039inch; Manufacturer Part Number: RC0402JR-071KL; Max Operating Temperature: 155°C; Min Operating Temperature: -55°C; Number of Terminations: 2; Package Quantity: 1; Packaging: Cut Tape; Pricing: 1=0.1, 10=0.018, 50=0.0102, 100=0.0083, 500=0.00528, 1000=0.00444, 5000=0.00307 (USD); Reach SVHC Compliant: No; Resistor Type: Thick Film; RoHS: Yes; RoHS Compliant: Yes; Series: RC; Stock: 15490862; Supplier 1: Digikey; Supplier Part Number 1: 311-1.0KJRCT-ND; Termination: SMD/SMT; Tolerance: 5%; Voltage Rating (AC): 50V; Width: 0.02inch; Library Name: defcon_badge.SchLib
R14 R15 = RMCF0402FT27R0: 27 Ω, 0402 Chip resistor; house_part_number: R-27-0402-1%-001; Manufacturer: Stackpole Electronics; Package Height: 0.3mm; Package Length: 1mm; Package Width: 0.5mm; Rated Power Dissipation (P): 63mW; Rated Temperature: 70°C; Reference Standard: AEC-Q200; Temperature Coefficient: 100ppm/°C; Tolerance: 1%; Working Voltage: 50V; Category: Chip SMD Resistors; Component Kind: Standard; ComponentLink1Description: https://datasheet.ciiva.com:443/pdfs/VipMasterIC/IC/SEIE/SEIE-S-A0009541969/SEIE-S-A0009541969-1.pdf?src-supplier=IHS+Markit; ComponentLink1URL: https://datasheet.ciiva.com:443/pdfs/VipMasterIC/IC/SEIE/SEIE-S-A0009541969/SEIE-S-A0009541969-1.pdf?src-supplier=IHS+Markit; ComponentLink2Description: https://www.seielect.com/catalog/SEI-RMCF_RMCP.pdf?src-supplier=Avnet; ComponentLink2URL: https://www.seielect.com/catalog/SEI-RMCF_RMCP.pdf?src-supplier=Avnet; ComponentLink3Description: https://www.seielect.com/catalog/sei-rmcf_rmcp.pdf?src-supplier=Digi-Key; ComponentLink3URL: https://www.seielect.com/catalog/sei-rmcf_rmcp.pdf?src-supplier=Digi-Key; ComponentLink4Description: http://www.seielect.com/Catalog/SEI-RMCF_RMCP.pdf?src-supplier=Digi-Key; ComponentLink4URL: http://www.seielect.com/Catalog/SEI-RMCF_RMCP.pdf?src-supplier=Digi-Key; Construction: Rectangular; JESD-609 Code: e3; Manufacturer Part Number: RMCF0402FT27R0; Max Operating Temperature: 155°C; Min Operating Temperature: -55°C; Mount: Surface Mount; Number of Pins: 2; Package Shape: Rectangular; Package Style: SMTMeter; Packaging: Tape and Reel; Pricing 1: 1=0.10000, 10=0.01500, 100=0.00610, 1000=0.00274, 2500=0.00238, 5000=0.00197 (USD); REACH SVHC: Yes; Resistor Type: FIXED RESISTOR; RoHS: Yes; RoHS Compliant: Yes; Stock 1: 3710; Supplier 1: Digi-Key; Supplier Part Number 1: RMCF0402FT27R0CT-ND; Technology: METAL GLAZE/THICK FILM; Terminal Finish: Nickel; Termination: Wraparound; Library Name: defcon_badge.SchLib
R16 R17 = RC0402FR-072K2L: 2.2 kΩ, 0402 Chip resistor; house_part_number: R-2.2k-0402-1%-001; Manufacturer: Yageo; Max Power Dissipation: 63mW; Power Rating: 62.5mW; Resistance Tolerance: 1%; Temperature Coefficient: 100ppm/°C; Voltage Rating: 50V; Case Code (Metric): 1005; Case/Package: 0402; Category: Chip SMD Resistors; Component Kind: Standard; ComponentLink1Description: https://datasheet.ciiva.com/11808/0900766b80c66297-11808786.pdf?src-supplier=Rs; ComponentLink1URL: https://datasheet.ciiva.com/11808/0900766b80c66297-11808786.pdf?src-supplier=Rs; ComponentLink2Description: https://datasheet.ciiva.com/7983/1018594-7983515.pdf?src-supplier=Element14; ComponentLink2URL: https://datasheet.ciiva.com/7983/1018594-7983515.pdf?src-supplier=Element14; ComponentLink3Description: https://datasheet.ciiva.com/12577/pyu-rc0402-51-rohs-l-6-r-12577429.pdf?src-supplier=Mouser; ComponentLink3URL: https://datasheet.ciiva.com/12577/pyu-rc0402-51-rohs-l-6-r-12577429.pdf?src-supplier=Mouser; ComponentLink4Description: https://datasheet.ciiva.com/46383/1018594-46383563.pdf?src-supplier=Element14; ComponentLink4URL: https://datasheet.ciiva.com/46383/1018594-46383563.pdf?src-supplier=Element14; ComponentLink5Description: https://datasheet.ciiva.com/7849/1018594-7849929.pdf?src-supplier=Element14; ComponentLink5URL: https://datasheet.ciiva.com/7849/1018594-7849929.pdf?src-supplier=Element14; ComponentLink6Description: https://datasheet.ciiva.com/7849/1018594-7849928.pdf?src-supplier=Element14; ComponentLink6URL: https://datasheet.ciiva.com/7849/1018594-7849928.pdf?src-supplier=Element14; ComponentLink7Description: https://datasheet.ciiva.com/16741/pyu-rc0402-51-rohs-l-6-r-16741015.pdf?src-supplier=Future+Electronics; ComponentLink7URL: https://datasheet.ciiva.com/16741/pyu-rc0402-51-rohs-l-6-r-16741015.pdf?src-supplier=Future+Electronics; Construction: Rectangular; Depth: 0.5mm; Features: Moisture Resistant; Height: 0.35mm; Lead Free: Lead Free; Length: 1mm; Manufacturer Part Number: RC0402FR-072K2L; Max Operating Temperature: 155°C; Military Standard: Not; Min Operating Temperature: -55°C; Number of Terminations: 2; Packaging: Tape and Reel; Pricing: 1=0.1, 20=0.0673, 150=0.0454, 1000=0.0247, 5000=0.0072 (USD); Reach SVHC Compliant: No; Resistor Type: Thick Film; RoHS: Yes; RoHS Compliant: Yes; Series: RC; Stock: 4866; Supplier 1: Future Electronics; Supplier Part Number 1: 9737263; Technology: THICK FILM; Termination: SMD/SMT; Tolerance: 1%; Voltage Rating (AC): 50V; Weight: 2.3E-05oz; Width: 0.5mm; Library Name: defcon_badge.SchLib
R45 = RC0402FR-07442KL: 442 kΩ, 0402 Chip resistor; house_part_number: R-442k-0402-1%-001; Manufacturer: Yageo; Max Power Dissipation: 63mW; Power Rating: 62.5mW; Temperature Coefficient: 100ppm/°C; Tolerance: 1%; Voltage Rating: 50V; Case Code (Metric): 1005; Case/Package: 0402; Category: Chip SMD Resistors; Component Kind: Standard; ComponentLink1Description: https://datasheet.ciiva.com/2453/pyu-r-int-thick-7-2453668.pdf?src-supplier=Digikey; ComponentLink1URL: https://datasheet.ciiva.com/2453/pyu-r-int-thick-7-2453668.pdf?src-supplier=Digikey; ComponentLink2Description: https://datasheet.ciiva.com/2453/pyu-r-int-thick-7-2453667.pdf?src-supplier=Digikey; ComponentLink2URL: https://datasheet.ciiva.com/2453/pyu-r-int-thick-7-2453667.pdf?src-supplier=Digikey; ComponentLink3Description: https://datasheet.ciiva.com/2453/pyu-r-int-thick-7-2453669.pdf?src-supplier=Digikey; ComponentLink3URL: https://datasheet.ciiva.com/2453/pyu-r-int-thick-7-2453669.pdf?src-supplier=Digikey; ComponentLink4Description: https://datasheet.ciiva.com/8885/pyu-rc0402-51-rohs-l-6-8885693.pdf?src-supplier=Avnet; ComponentLink4URL: https://datasheet.ciiva.com/8885/pyu-rc0402-51-rohs-l-6-8885693.pdf?src-supplier=Avnet; Construction: Rectangular; Contact Plating: Tin,Lead; Depth: 0.5mm; Features: Moisture Resistant; Height: 0.016inch; Length: 1mm; Manufacturer Part Number: RC0402FR-07442KL; Max Operating Temperature: 155°C; Military Standard: Not; Min Operating Temperature: -55°C; Number of Terminations: 2; Package Quantity: 1; Packaging: Tape and Reel; Pricing: 10000=0.0012, 50000=0.0011, 250000=0.001, 500000=0.001, 1500000=0.0009 (USD); RoHS: Yes; RoHS Compliant: Yes; Series: RC; Stock: 40000; Supplier 1: Future Electronics; Supplier Part Number 1: 1075035; Termination: SMD/SMT; Voltage Rating (DC): 50V; Width: 0.02inch; Library Name: defcon_badge.SchLib
TP1 TP3-TP47 = test_point-1: Test point; pickable: no; Library Name: defcon_badge.SchLib
U1 = RP2350-A: Microcontroller, QFN-60; Manufacturer: Raspberry Pi; Case/Package: QFN60; Component Kind: Standard; house_part_number: RP2350-A; Manufacturer Part Number: RP2350-A; Library Name: defcon_badge.SchLib
U4 = LIS3DHTR: 3-Axis accelerometer, 16-bit, I2C/SPI, LGA-16; Manufacturer: STMicroelectronics; Axis: X, Y, Z; Bandwidth: 5kHz; Interface: I2C,SPI; Max Supply Voltage: 3.6V; Min Supply Voltage: 1.71V; Operating Supply Current: 11uA; Operating Supply Voltage: 2.5V; Output Type: Digital; Resolution: 16b; Sensor Type: 3 Axis; Category: Accelerometers; Component Kind: Standard; ComponentLink1Description: https://datasheet.ciiva.com/7621/1718591-7621744.pdf?src-supplier=Element14; ComponentLink1URL: https://datasheet.ciiva.com/7621/1718591-7621744.pdf?src-supplier=Element14; ComponentLink2Description: https://datasheet.ciiva.com/5296/cd00274221-117431-5296513.pdf?src-supplier=Mouser; ComponentLink2URL: https://datasheet.ciiva.com/5296/cd00274221-117431-5296513.pdf?src-supplier=Mouser; ComponentLink3Description: https://datasheet.ciiva.com/2810/cd00274221-2810009.pdf?src-supplier=Digikey; ComponentLink3URL: https://datasheet.ciiva.com/2810/cd00274221-2810009.pdf?src-supplier=Digikey; ComponentLink4Description: https://datasheet.ciiva.com/2810/cd00274221-2810008.pdf?src-supplier=Digikey; ComponentLink4URL: https://datasheet.ciiva.com/2810/cd00274221-2810008.pdf?src-supplier=Digikey; ComponentLink5Description: https://datasheet.ciiva.com/2810/cd00274221-2810010.pdf?src-supplier=Digikey; ComponentLink5URL: https://datasheet.ciiva.com/2810/cd00274221-2810010.pdf?src-supplier=Digikey; ComponentLink6Description: https://datasheet.ciiva.com/48495/cd00274221-48495849.pdf?src-supplier=Avnet; ComponentLink6URL: https://datasheet.ciiva.com/48495/cd00274221-48495849.pdf?src-supplier=Avnet; ComponentLink7Description: https://datasheet.ciiva.com/3271/cd00274221-3271807.pdf?src-supplier=Avnet; ComponentLink7URL: https://datasheet.ciiva.com/3271/cd00274221-3271807.pdf?src-supplier=Avnet; ComponentLink8Description: https://datasheet.ciiva.com/16216/cd00274221-16216362.pdf?src-supplier=Future+Electronics; ComponentLink8URL: https://datasheet.ciiva.com/16216/cd00274221-16216362.pdf?src-supplier=Future+Electronics; Depth: 3mm; Height: 1mm; house_part_number: LIS3DHTR; Lead Free: Lead Free; Length: 3mm; Manufacturer Part Number: LIS3DHTR; Max Operating Temperature: 85°C; Max Supply Voltage (DC): 3.6V; Min Operating Temperature: -40°C; Min Supply Voltage (DC): 1.71V; Package Quantity: 4000; Packaging: Tape and Reel; Pins: 16; Pricing: 4000=0.94095, 8000=0.92155, 16000=0.90215 (USD); Radiation Hardening: No; Reach SVHC Compliant: No; RoHS: Yes; RoHS Compliant: Yes; Series: -; Stock: 40000; Supplier: Avnet; Supplier 1: LCSC; Supplier Part Number: LIS3DHTR; Supplier Part Number 1: C15134; Width: 3mm; Library Name: defcon_badge.SchLib
U5 = W25Q32JVSSIQ: 32 Mb SPI flash memory; Manufacturer: Winbond; Access Time: 6ns; Frequency: 133MHz; Interface: SPI,Serial; Memory Format: Non-Volatile,FLASH,NOR; Operating Supply Voltage: 3V; Page Size: 256B; Component Kind: Standard; ComponentLink1Description: https://www.winbond.com/hq/product/code-storage-flash-memory/serial-nor-flash/?__locale=en&partNo=W25Q32JV&src-supplier=Avnet; ComponentLink1URL: https://www.winbond.com/hq/product/code-storage-flash-memory/serial-nor-flash/?__locale=en&partNo=W25Q32JV&src-supplier=Avnet; ComponentLink2Description: http://www.winbond.com/resource-files/w25q32jv%20revg%2003272018%20plus.pdf?src-supplier=Digikey; ComponentLink2URL: http://www.winbond.com/resource-files/w25q32jv%20revg%2003272018%20plus.pdf?src-supplier=Digikey; Height: 2.16mm; house_part_number: W25Q32JVSSIQ; Manufacturer Part Number: W25Q32JVSSIQ; Max Operating Temperature: 85°C; Memory Size: 32Mb; Min Operating Temperature: -40°C; Mounting Technology: Surface Mount; Packaging: Rail/Tube; Pins: 8; Pricing: 1=0.7312, 10=0.6566, 25=0.6437, 90=0.5704, 270=0.5588, 540=0.5419, 1080=0.5254, 5040=0.479 (USD); RoHS: Yes; RoHS Compliant: Yes; Series: SpiFlash®; Stock: 1509; Supplier 1: Arrow Electronics; Supplier Part Number 1: W25Q32JVSSIQ; Library Name: defcon_badge.SchLib
U6 = PCF8563T/5,518: Real-Time Clock, I2C, SOIC-8; Manufacturer: NXP Semiconductors; Max Supply Voltage: 5.5V; Min Supply Voltage: 1.8V; Time Format: HH:MM:SS; Category: Real Time Clocks; Component Kind: Standard; ComponentLink1Description: https://datasheet.ciiva.com/1238/pcf8563-1238727.pdf?src-supplier=Digi-Key; ComponentLink1URL: https://datasheet.ciiva.com/1238/pcf8563-1238727.pdf?src-supplier=Digi-Key; ComponentLink2Description: https://datasheet.ciiva.com/1238/pcf8563-1238728.pdf?src-supplier=Digi-Key; ComponentLink2URL: https://datasheet.ciiva.com/1238/pcf8563-1238728.pdf?src-supplier=Digi-Key; ComponentLink3Description: https://datasheet.ciiva.com/1238/pcf8563-1238773.pdf?src-supplier=Digi-Key; ComponentLink3URL: https://datasheet.ciiva.com/1238/pcf8563-1238773.pdf?src-supplier=Digi-Key; ComponentLink4Description: https://datasheet.ciiva.com/8145/pcf8563-8145180.pdf?src-supplier=Avnet; ComponentLink4URL: https://datasheet.ciiva.com/8145/pcf8563-8145180.pdf?src-supplier=Avnet; ComponentLink5Description: https://datasheet.ciiva.com/16287/pcf8563-16287110.pdf?src-supplier=Future+Electronics; ComponentLink5URL: https://datasheet.ciiva.com/16287/pcf8563-16287110.pdf?src-supplier=Future+Electronics; house_part_number: PCF8563T/5,518; Interface: I2C; Lead Free: Lead Free; Logic Function: Clock; Manufacturer Part Number: PCF8563T/5,518; Max Operating Temperature: 85°C; Min Operating Temperature: -40°C; Mounting Technology: Surface Mount; Nominal Supply Voltage: 5.5V; Package Quantity: 2500; Packaging: Tape and Reel; Pins: 8; Pricing: 2500=0.4908, 5000=0.4612, 12500=0.4404 (USD); Radiation Hardening: No; RoHS: Yes; RoHS Compliant: Yes; Stock: 7500; Supplier: Arrow Electronics; Supplier 1: LCSC; Supplier Part Number: PCF8563T/5,518; Supplier Part Number 1: C7440; Temperature Grade: INDUSTRIAL; Library Name: defcon_badge.SchLib
U7 = APS6404L-3SQR-SN: 8M x8 PSRAM, QSPI, PDSO8; Manufacturer: AP Memory; Component Kind: Standard; house_part_number: APS6404L-3SQR-SN; Manufacturer Part Number: APS6404L-3SQR-SN; Pricing: 1=1.46, 10=1.34, 50=1.34, 100=1.16, 500=1.15, 1000=1.15, 3000=0.974, 10000=0.974 (USD); RoHS: No; Stock: 41293; Supplier: Mouser; Supplier Part Number 1: 878-APS6404L-3SQR-SN; Library Name: defcon_badge.SchLib
Y1 = TAXM12M4RLBDDT2T: 12 MHz, 10 ppm, 20 pF, 3225 Crystal; Component Kind: Standard; house_part_number: TAXM12M4RLBDDT2T; Manufacturer Part Number: TAXM12M4RLBDDT2T; Supplier 1: LCSC; Supplier Part Number 1: C133334; Library Name: defcon_badge.SchLib
Y2 = SC-20S,32.768KHZ,20PPM,12.5PF: 32.768kHz Crystal, 12.5pf, 2012; Manufacturer: Seiko; Component Kind: Standard; house_part_number: SC-20S,32.768KHZ,20PPM,12.5PF; Manufacturer Part Number: SC-20S,32.768KHZ,20PPM,12.5PF; Pricing: 5=0.2255, 50=0.1827, 150=0.1644, 500=0.1415, 3000=0.1313, 6000=0.1252 (USD); RoHS: No; Stock: 8860; Supplier 1: LCSC; Supplier Part Number 1: C97607; Library Name: defcon_badge.SchLib
